# Altium schematic sheet Peripherals.SchDoc(Peripherals)
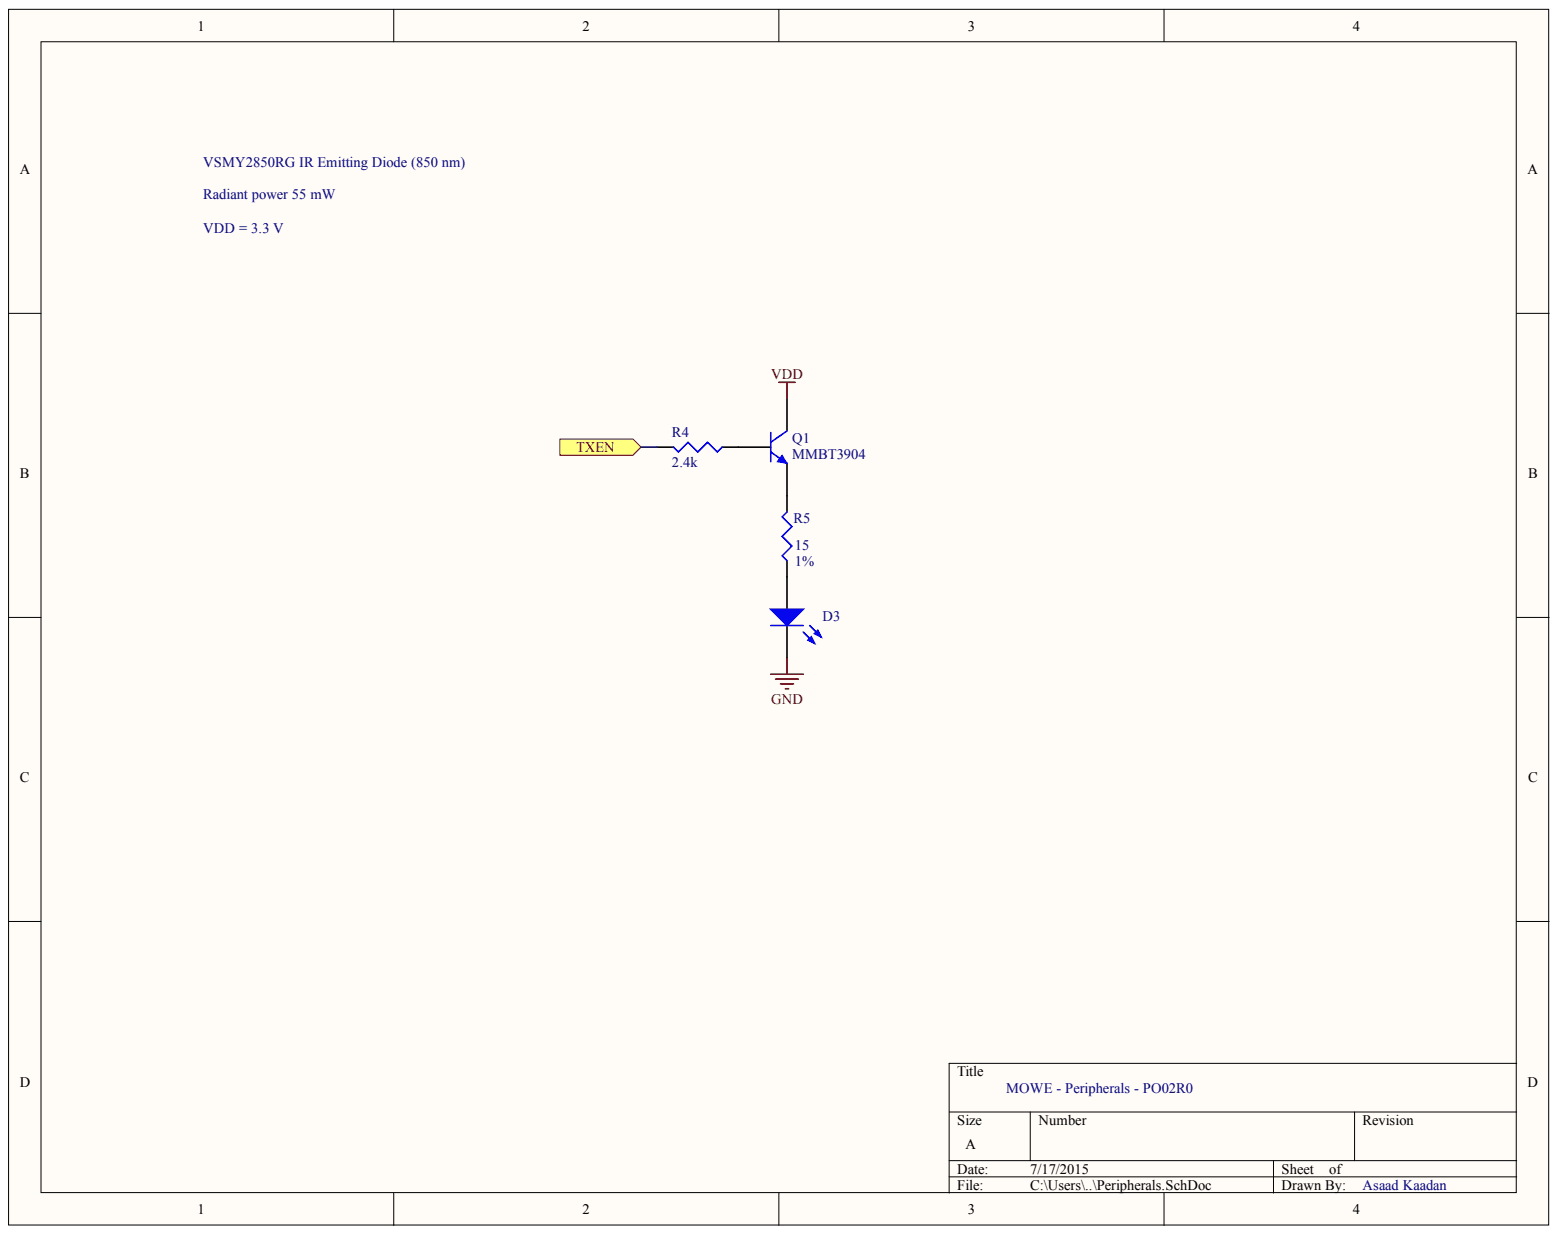
[diagram p0: Peripherals.SchDoc]

## Parts
D3  LED3  [SODFL470X280-2N]  (value not drawn)
Q1  MMBT3904  [SOT-23_N]
R4  value 2.4k  [6-0805_L]  (hidden comment: Res3)
R5  value 15  Tolerance: 1%  [6-0805_L]  (hidden comment: Res3)

## Ports
TXEN

## Nets
GND: D3.2
VDD: Q1.3
TXEN: R4.2 ; port TXEN
NetD3_1: D3.1 R5.1
NetQ1_1: Q1.1 R5.2
NetQ1_2: Q1.2 R4.1

## Library data of the parts
D3 = LED3: Typical BLUE SiC LED; Component Kind: Standard; DatasheetVersion: 16-Apr-2002; LatestRevisionDate: 17-Dec-2003; LatestRevisionNote: PCB Footprint replaced.; Library Name: Miscellaneous Devices.IntLib; PackageDescription: SM LED; 2 C-Bend Leads; Body 3.5 x 2.8 x 1.9 mm, inc leads (LxWxH typ); PackageReference: 3.5X2.8X1.9; PackageVersion: 16-Apr-2002; Published: 8-Jun-2000; Signal Integrity: LED; Simulation: LED3; Supplier 1: Digi-Key; Supplier Part Number 1: VSMY2850GCT-ND; Publisher: Altium Limited
Q1 = 2N3904: NPN General Purpose Amplifier; Component Kind: Standard; ComponentLink1Description: Manufacturer Link; ComponentLink1URL: http://www.fairchildsemi.com/; ComponentLink2Description: Datasheet; ComponentLink2URL: http://www.fairchildsemi.com/ds/2N%2F2N3904.pdf; DatasheetVersion: 1997; LatestRevisionDate: 15-Jan-2003; LatestRevisionNote: PCB Footprint 'BCY-W3/D4.7' replaced; Library Name: Miscellaneous Devices.IntLib; PackageDescription: TO, Flat Index; 3 In-Line, Axial Leads; Body Dia. 4.5mm; Leads 0.51 x 0.48 mm (max); PackageReference: TO-92A; PackageVersion: Sep-1998; Published: 8-Jun-2000; Signal Integrity: 2N3904; Simulation: 2N3904; Supplier 1: Digi-Key; Supplier Part Number 1: MMBT3904-FDIDKR-ND; Publisher: Altium Limited
R4 R5 = Res3: Resistor; Component Kind: Standard; LatestRevisionDate: 29-May-2009; LatestRevisionNote: IPC-7351 Footprint Added.; Library Name: Miscellaneous Devices.IntLib; PackageDescription: Chip Resistor; PackageReference: J1-0603; Published: 8-Jun-2000; Signal Integrity: Res; Simulation: RESISTOR; Supplier 1: Digi-Key; Publisher: Altium Limited
  R4: Supplier Part Number 1: P2.4KACT-ND
  R5: Supplier Part Number 1: RNCP0805FTD15R0CT-ND
